# Altium schematic sheet bmp180 barometer Modular.SchDoc
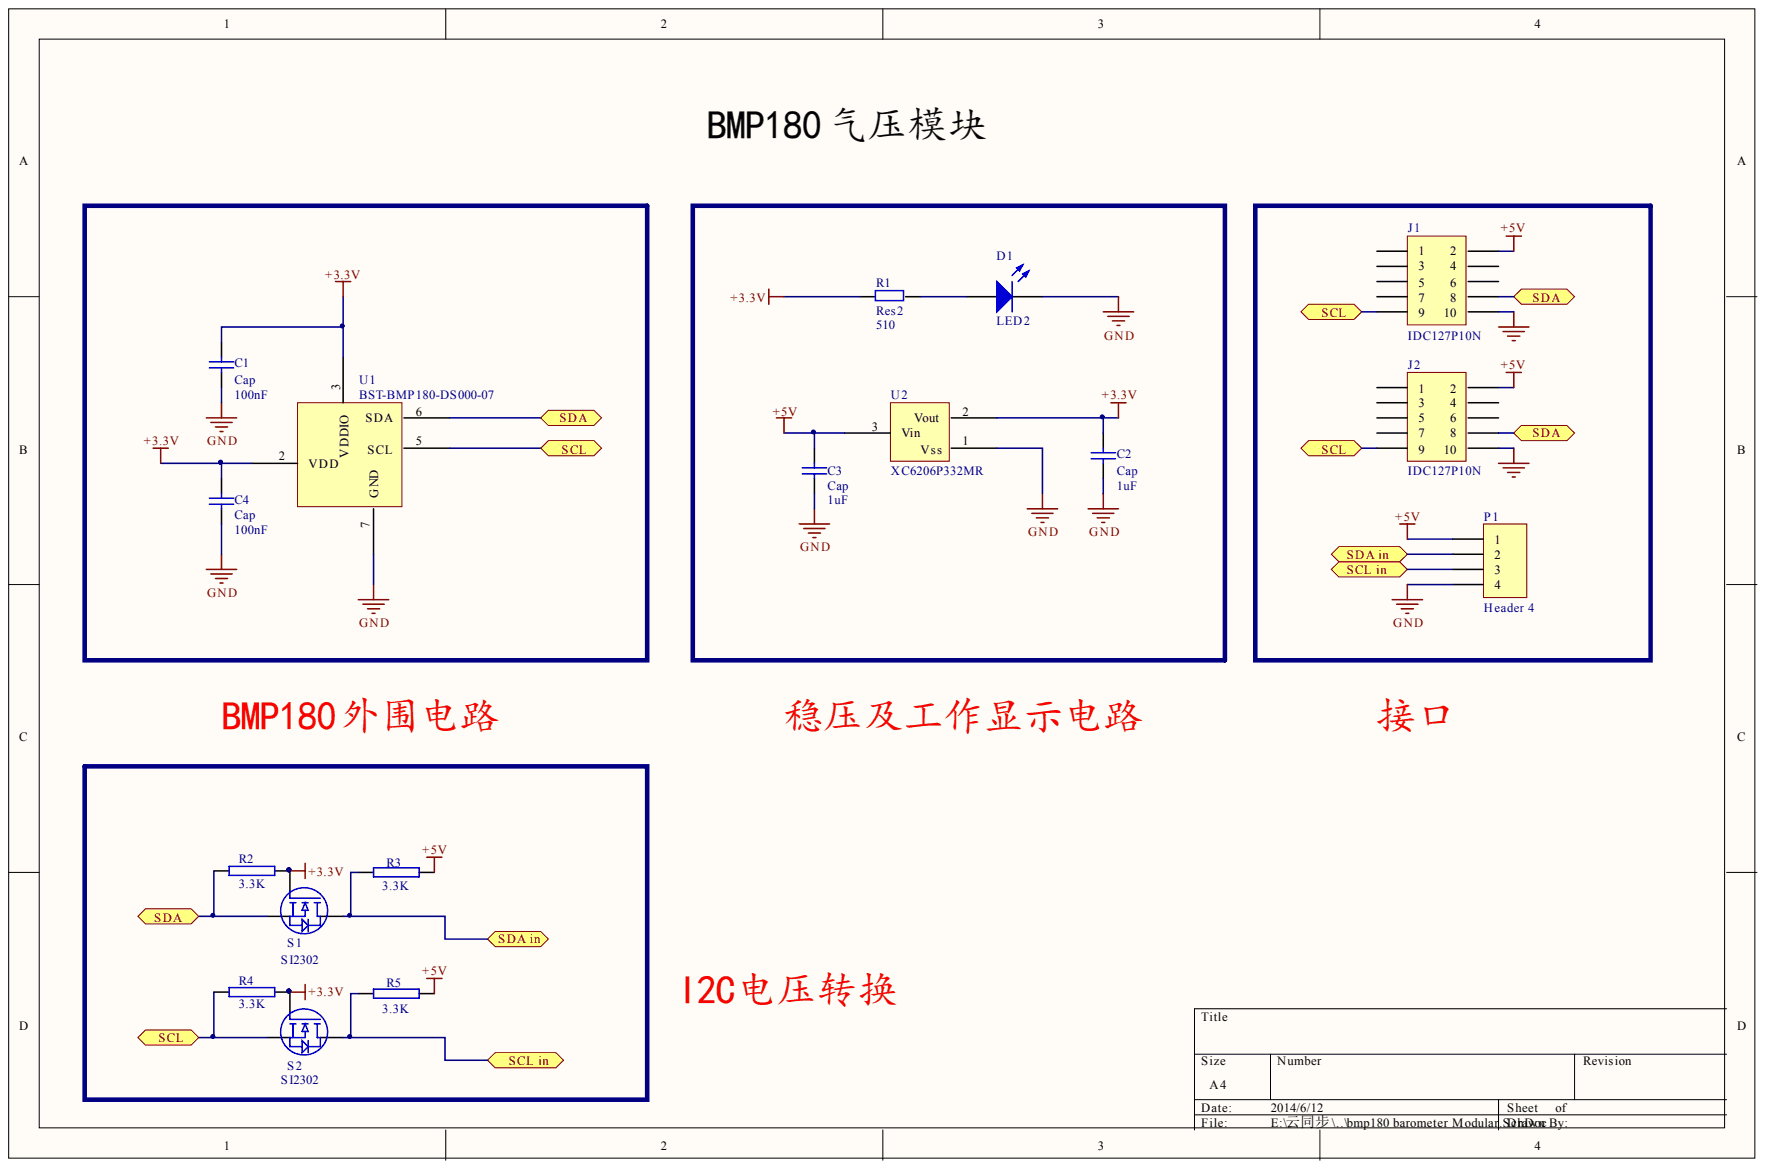
[diagram p0: bmp180 barometer Modular.SchDoc]

## Parts
C1  Cap  value 100nF
C2  Cap  value 1uF
C3  Cap  value 1uF
C4  Cap  value 100nF
D1  LED2
J1  IDC127P10N
J2  IDC127P10N
P1  Header 4
R1  Res2  value 510
R2  3.3K
R3  3.3K
R4  3.3K
R5  3.3K
S1  SI2302
S2  SI2302
U1  BST-BMP180-DS000-07
U2  XC6206P332MR

## Ports
SCL, SCL IN, SDA, SDA IN

## Nets
+3.3V: C1.2 C2.2 C4.2 R1.2 R2.1 R4.1 S1.1 S2.1 U1.2(VDD) U1.3(V_D_DIO) U2.2
+5V: C3.2 J1.2 J2.2 P1.1 R3.1 R5.1 U2.3
GND: C1.1 C2.1 C3.1 C4.1 D1.2 J1.10 J2.10 P1.4 U1.7(G_ND) U2.1
SCL: J1.9 J2.9 R4.2 S2.2 U1.5(S_CL) ; port SCL
SDA: J1.8 J2.8 R2.2 S1.2 U1.6(SDA) ; port SDA
NetD1_1: D1.1 R1.1
NetP1_2: P1.2 R3.2 S1.3 ; port SDA IN
NetP1_3: P1.3 R5.2 S2.3 ; port SCL IN
N00004:
N00005:
N00006:
N00007:

Not connected: J1 1 3 4 5 6 7; J2 1 3 4 5 6 7

## Library data of the parts
C1-C4 D1 J1 J2 P1 R1-R5 S1 S2 U1 U2 = (no symbol name)
  C1-C4: Published: 8-Jun-2000; LatestRevisionDate: 17-Jul-2002; LatestRevisionNote: Re-released for DXP Platform.; PackageReference: RAD-0.3; PackageDescription: Radial Cap, Thru-Hole; 2 Leads; 0.3 in Pin Spacing
  D1: Published: 8-Jun-2000; LatestRevisionDate: 17-Jul-2002; LatestRevisionNote: Re-released for DXP Platform.; PackageVersion: Feb-2002; PackageReference: 3.2X1.6X1.1; PackageDescription: SM LED; 2 Flat Leads
  J1 J2 P1: LatestRevisionDate: 17-Jul-2002; LatestRevisionNote: Re-released for DXP Platform.
  R1: Published: 8-Jun-2000; LatestRevisionDate: 17-Jul-2002; LatestRevisionNote: Re-released for DXP Platform.; PackageReference: AXIAL-0.4; PackageDescription: Axial Device, Thru-Hole; 2 Leads; 0.4 in Pin Spacing
  C1-C4 D1 J1 J2 P1 R1: Publisher: Altium Limited
